# Altium schematic sheet H-9220 Backplane Board - Interface (REV07).SchDoc
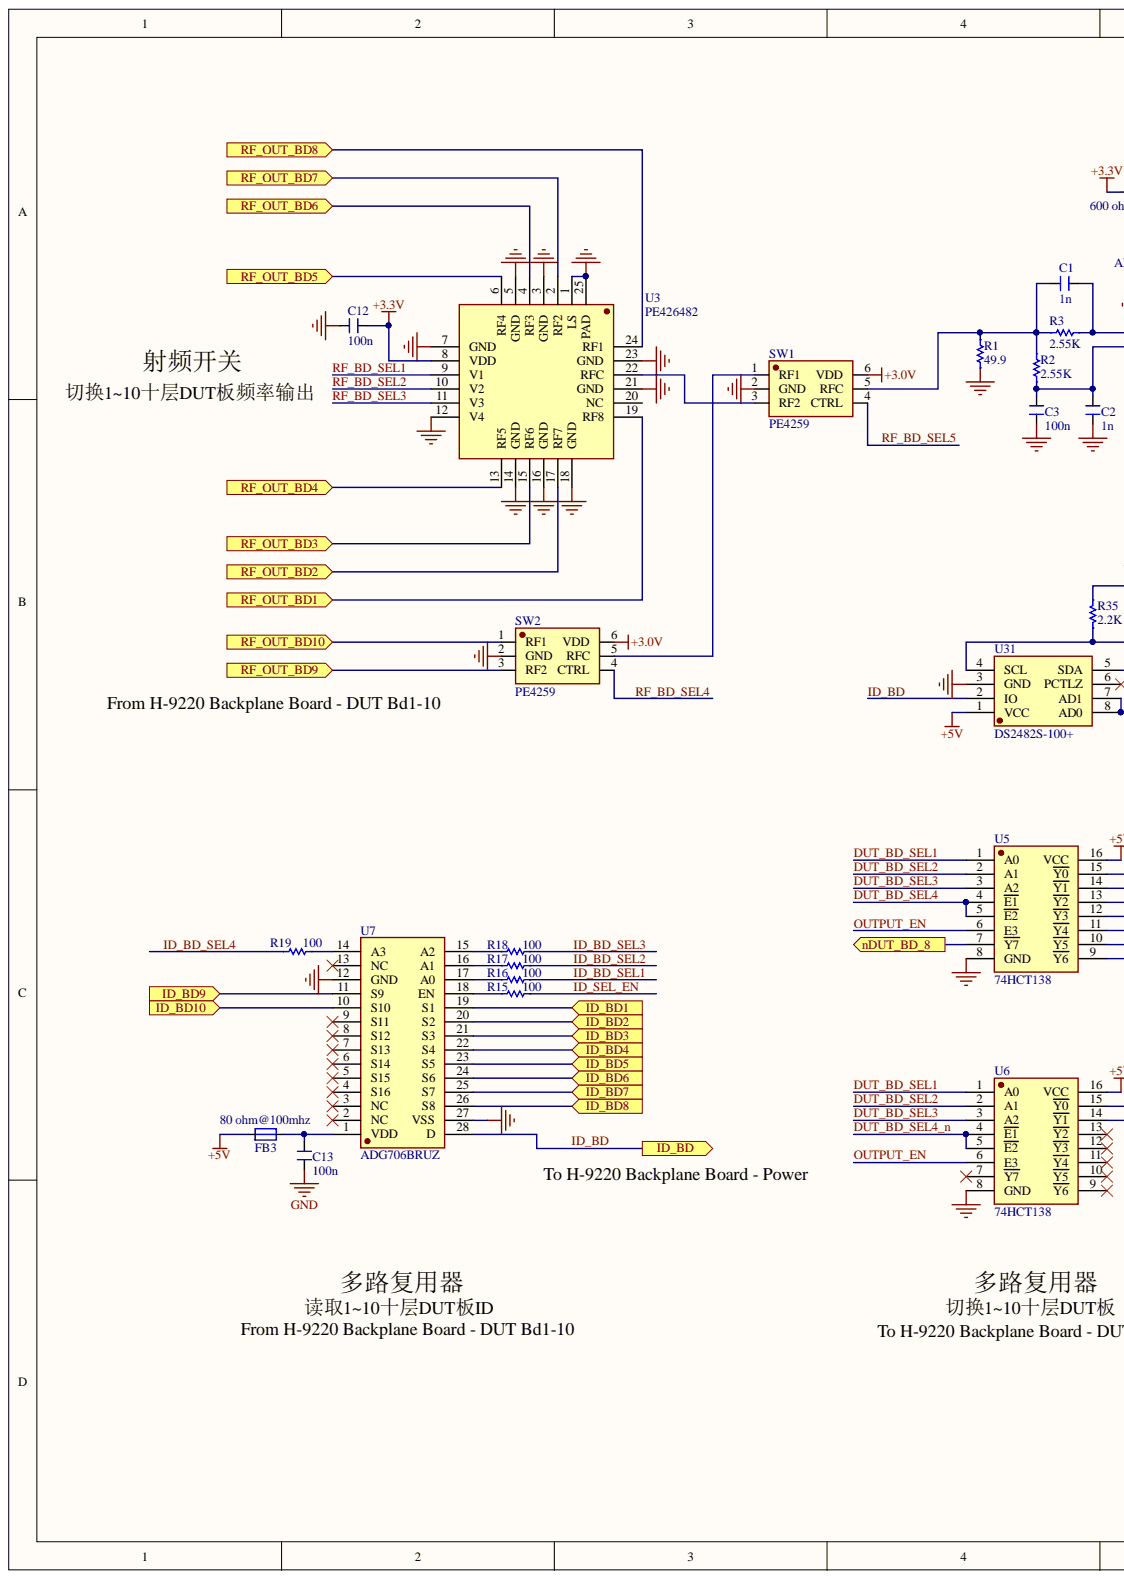
[diagram p0: H-9220 Backplane Board - Interface (REV07).SchDoc, left]
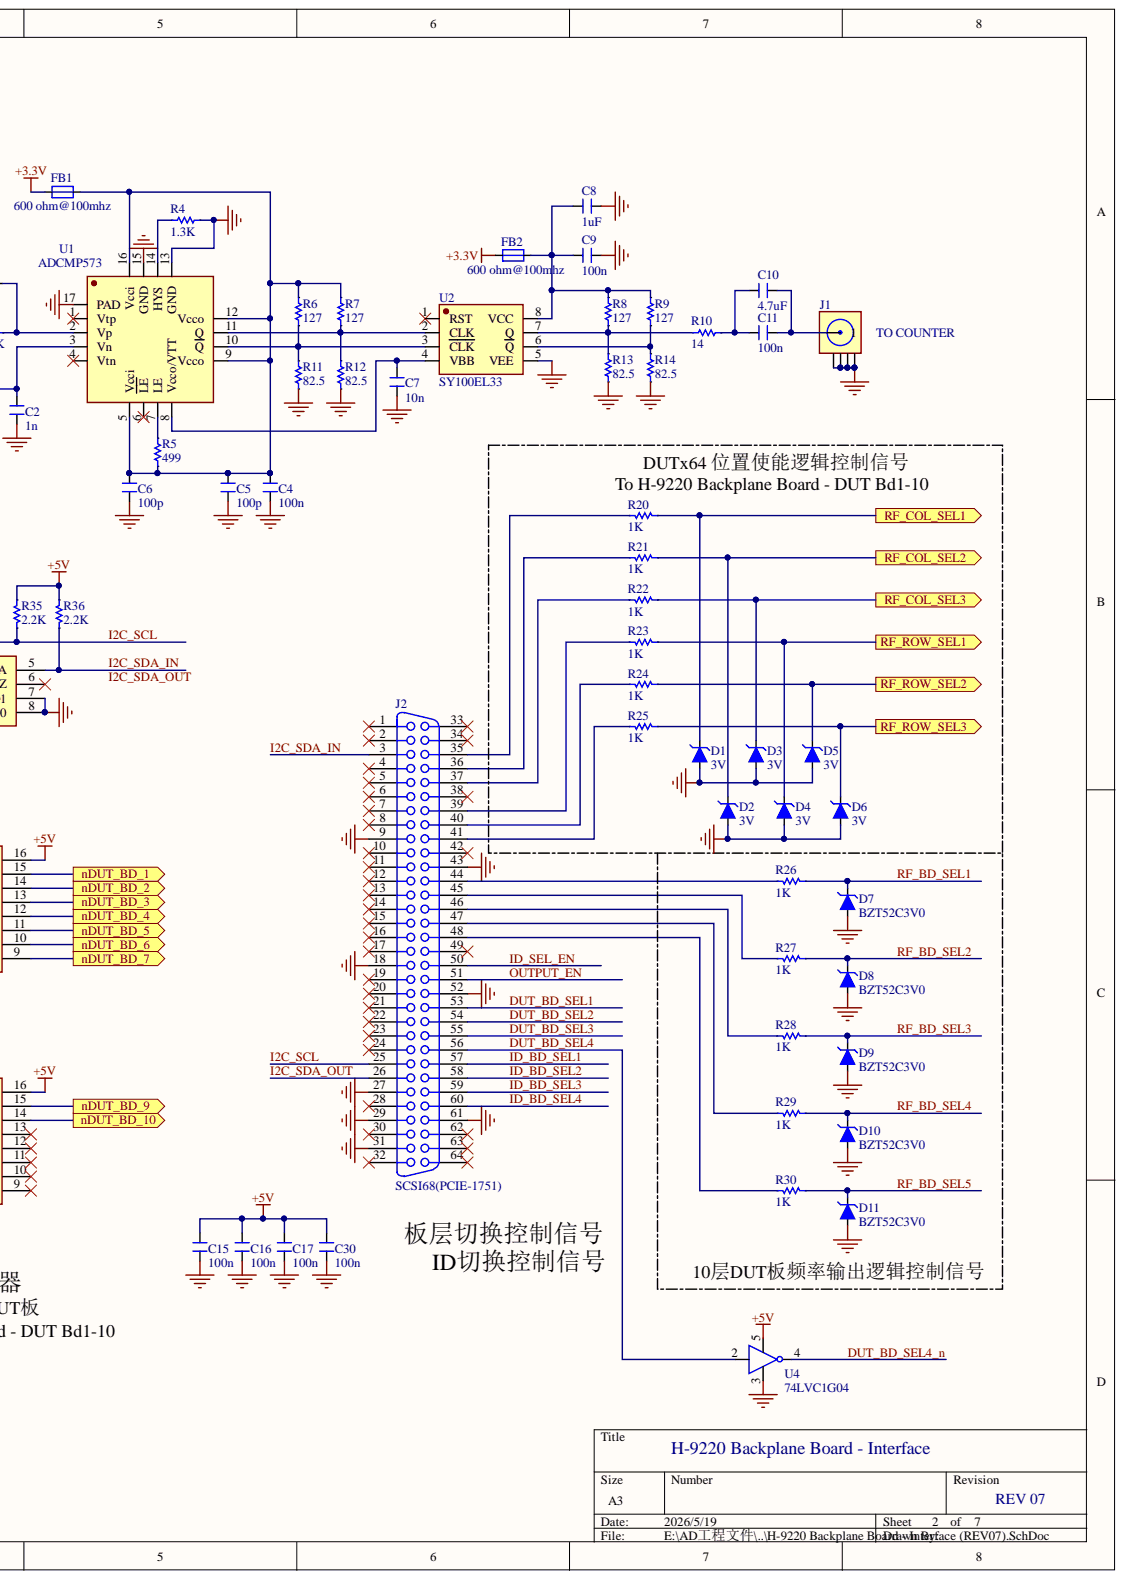
[diagram p1: H-9220 Backplane Board - Interface (REV07).SchDoc, right]

## Parts
C1  1n  [C-0603]
C2  1n  [C-0603]
C3  100n  [C-0603]
C4  100n  [C-0603]
C5  100p  [C-0603]
C6  100p  [C-0603]
C7  10n  [C-0603]
C8  1uF  [C-0805]
C9  100n  [C-0603]
C10  4.7uF  [C-0805]
C11  100n  [C-0603]
C12  100n  [C-0603]
C13  100n  [C-0603]
C15  100n  [C-0603]
C16  100n  [C-0603]
C17  100n  [C-0603]
C30  100n  [C-0603]
D1  3V  [SOD-123W]
D2  3V  [SOD-123W]
D3  3V  [SOD-123W]
D4  3V  [SOD-123W]
D5  3V  [SOD-123W]
D6  3V  [SOD-123W]
D7  BZT52C3V0  [SOD-123W]
D8  BZT52C3V0  [SOD-123W]
D9  BZT52C3V0  [SOD-123W]
D10  BZT52C3V0  [SOD-123W]
D11  BZT52C3V0  [SOD-123W]
FB1  600 ohm@100mhz  [R-0805]
FB2  600 ohm@100mhz  [R-0805]
FB3  80 ohm@100mhz  [R-0805]
J1  TO COUNTER  [SMA-V]
J2  SCSI68(PCIE-1751)  [CON-2x32P (P=2.54MM)]
R1  49.9  [R-0603]
R2  2.55K  [R-0603]
R3  2.55K  [R-0603]
R4  1.3K  [R-0603]
R5  499  [R-0603]
R6  127  [R-0603]
R7  127  [R-0603]
R8  127  [R-0603]
R9  127  [R-0603]
R10  14  [R-0603]
R11  82.5  [R-0603]
R12  82.5  [R-0603]
R13  82.5  [R-0603]
R14  82.5  [R-0603]
R15  100  [R-0603]
R16  100  [R-0603]
R17  100  [R-0603]
R18  100  [R-0603]
R19  100  [R-0603]
R20  1K  [R-0603]
R21  1K  [R-0603]
R22  1K  [R-0603]
R23  1K  [R-0603]
R24  1K  [R-0603]
R25  1K  [R-0603]
R26  1K  [R-0603]
R27  1K  [R-0603]
R28  1K  [R-0603]
R29  1K  [R-0603]
R30  1K  [R-0603]
R35  2.2K  [R-0805]
R36  2.2K  [R-0805]
SW1  PE4259  [SC70-6]
SW2  PE4259  [SC70-6]
U1  ADCMP573  [QFN-16 (P=0.5mm)]
U2  SY100EL33  [SOIC-08 (Body=3.9MM)]
U3  PE426482  [QFN-24 (P=0.5MM)]
U4  74LVC1G04  [SOT23-5]
U5  74HCT138  [SOIC-16 (BODY=3.9MM)]
U6  74HCT138  [SOIC-16 (BODY=3.9MM)]
U7  ADG706BRUZ  [TSSOP-28 (P=0.65MM)]
U31  DS2482S-100+  [SOIC-08 (Body=3.9MM)]

## Ports
ID_BD, ID_BD1, ID_BD2, ID_BD3, ID_BD4, ID_BD5, ID_BD6, ID_BD7, ID_BD8, ID_BD9, ID_BD10, nDUT_BD_1, nDUT_BD_2, nDUT_BD_3, nDUT_BD_4, nDUT_BD_5, nDUT_BD_6, nDUT_BD_7, nDUT_BD_8, nDUT_BD_9, nDUT_BD_10, RF_COL_SEL1, RF_COL_SEL2, RF_COL_SEL3, RF_OUT_BD1, RF_OUT_BD2, RF_OUT_BD3, RF_OUT_BD4, RF_OUT_BD5, RF_OUT_BD6, RF_OUT_BD7, RF_OUT_BD8, RF_OUT_BD9, RF_OUT_BD10, RF_ROW_SEL1, RF_ROW_SEL2, RF_ROW_SEL3

## Nets
+3.0V: SW1.6(VDD) SW2.6(VDD)
+3.3V: C12.1 FB1.1 FB2.1 U3.8(VDD)
+5V: C15.1 C16.1 C17.1 C30.1 FB3.1 R35.2 R36.2 U4.5 U5.16(VCC) U6.16(VCC) U31.1(VCC)
GND: C2.2 C3.2 C4.1 C5.2 C6.2 C7.1 C8.2 C9.2 C12.2 C13.1 C15.2 C16.2 C17.2 C30.2 D1.A D2.A D3.A D4.A D5.A D6.A D7.A D8.A D9.A D10.A D11.A J1.2 J1.3 J1.4 J1.5 J2.9 J2.18 J2.27 J2.29 J2.31 J2.43 J2.52 J2.61 R1.2 R4.1 R11.1 R12.1 R13.1 R14.1 SW1.2(GND) SW2.2(GND) U1.13(GND) U1.15(GND) U1.17(PAD) U2.5(VEE) U3.1(LS) U3.3(GND) U3.5(GND) U3.7(GND) U3.12(V4) U3.14(GND) U3.16(GND) U3.18(GND) U3.21(GND) U3.23(GND) U3.25(PAD) U4.3 U5.8(GND) U6.8(GND) U7.12(GND) U7.27(VSS) U31.3(GND) U31.7(AD1) U31.8(AD0)
DUT_BD_SEL1: J2.53 U5.1(A0) U6.1(A0)
DUT_BD_SEL2: J2.54 U5.2(A1) U6.2(A1)
DUT_BD_SEL3: J2.55 U5.3(A2) U6.3(A2)
DUT_BD_SEL4: J2.56 U4.2 U5.4(E1) U5.5(E2)
DUT_BD_SEL4_n: U4.4 U6.4(E1) U6.5(E2)
I2C_SCL: J2.25 R35.1 U31.4(SCL)
I2C_SDA_IN: J2.3 J2.26 R36.1 U31.5(SDA)
ID_BD: U7.28(D) U31.2(IO) ; port ID_BD
ID_BD1: U7.19(S1) ; port ID_BD1
ID_BD2: U7.20(S2) ; port ID_BD2
ID_BD3: U7.21(S3) ; port ID_BD3
ID_BD4: U7.22(S4) ; port ID_BD4
ID_BD5: U7.23(S5) ; port ID_BD5
ID_BD6: U7.24(S6) ; port ID_BD6
ID_BD7: U7.25(S7) ; port ID_BD7
ID_BD8: U7.26(S8) ; port ID_BD8
ID_BD9: U7.11(S9) ; port ID_BD9
ID_BD10: U7.10(S10) ; port ID_BD10
ID_BD_SEL1: J2.57 R16.1
ID_BD_SEL2: J2.58 R17.1
ID_BD_SEL3: J2.59 R18.1
ID_BD_SEL4: J2.60 R19.1
ID_SEL_EN: J2.50 R15.1
nDUT_BD_1: U5.15(Y0) ; port nDUT_BD_1
nDUT_BD_2: U5.14(Y1) ; port nDUT_BD_2
nDUT_BD_3: U5.13(Y2) ; port nDUT_BD_3
nDUT_BD_4: U5.12(Y3) ; port nDUT_BD_4
nDUT_BD_5: U5.11(Y4) ; port nDUT_BD_5
nDUT_BD_6: U5.10(Y5) ; port nDUT_BD_6
nDUT_BD_7: U5.9(Y6) ; port nDUT_BD_7
nDUT_BD_8: U5.7(Y7) ; port nDUT_BD_8
nDUT_BD_9: U6.15(Y0) ; port nDUT_BD_9
nDUT_BD_10: U6.14(Y1) ; port nDUT_BD_10
OUTPUT_EN: J2.51 U5.6(E3) U6.6(E3)
RF_BD_SEL1: D7.K R26.1 U3.9(V1)
RF_BD_SEL2: D8.K R27.1 U3.10(V2)
RF_BD_SEL3: D9.K R28.1 U3.11(V3)
RF_BD_SEL4: D10.K R29.1 SW2.4(CTRL)
RF_BD_SEL5: D11.K R30.1 SW1.4(CTRL)
RF_COL_SEL1: D1.K R20.1 ; port RF_COL_SEL1
RF_COL_SEL2: D2.K R21.1 ; port RF_COL_SEL2
RF_COL_SEL3: D3.K R22.1 ; port RF_COL_SEL3
RF_OUT_BD1: U3.19(RF8) ; port RF_OUT_BD1
RF_OUT_BD2: U3.17(RF7) ; port RF_OUT_BD2
RF_OUT_BD3: U3.15(RF6) ; port RF_OUT_BD3
RF_OUT_BD4: U3.13(RF5) ; port RF_OUT_BD4
RF_OUT_BD5: U3.6(RF4) ; port RF_OUT_BD5
RF_OUT_BD6: U3.4(RF3) ; port RF_OUT_BD6
RF_OUT_BD7: U3.2(RF2) ; port RF_OUT_BD7
RF_OUT_BD8: U3.24(RF1) ; port RF_OUT_BD8
RF_OUT_BD9: SW2.3(RF2) ; port RF_OUT_BD9
RF_OUT_BD10: SW2.1(RF1) ; port RF_OUT_BD10
RF_ROW_SEL1: D4.K R23.1 ; port RF_ROW_SEL1
RF_ROW_SEL2: D5.K R24.1 ; port RF_ROW_SEL2
RF_ROW_SEL3: D6.K R25.1 ; port RF_ROW_SEL3
NetC1_1: C1.1 R1.1 R2.2 R3.1 SW1.5(RFC)
NetC1_2: C1.2 R3.2 U1.2(Vp)
NetC2_1: C2.1 C3.1 R2.1 U1.3(Vn)
NetC4_2: C4.2 C5.1 C6.1 FB1.2 R5.2 R6.2 R7.2 U1.5(Vcci) U1.9(Vcco) U1.12(Vcco) U1.16(Vcci)
NetC7_2: C7.2 U1.8(Vcco/VTT) U2.4(VBB)
NetC8_1: C8.1 C9.1 FB2.2 R8.2 R9.2 U2.8(VCC)
NetC10_1: C10.1 C11.1 R10.1
NetC10_2: C10.2 C11.2 J1.1
NetC13_2: C13.2 FB3.2 U7.1(VDD)
NetJ2_35: J2.35 R20.2
NetJ2_36: J2.36 R21.2
NetJ2_37: J2.37 R22.2
NetJ2_39: J2.39 R23.2
NetJ2_40: J2.40 R24.2
NetJ2_41: J2.41 R25.2
NetJ2_44: J2.44 R26.2
NetJ2_45: J2.45 R27.2
NetJ2_46: J2.46 R28.2
NetJ2_47: J2.47 R29.2
NetJ2_48: J2.48 R30.2
NetR4_2: R4.2 U1.14(HYS)
NetR5_1: R5.1 U1.7(LE)
NetR6_1: R6.1 R11.2 U1.10(Q) U2.3(CLK)
NetR7_1: R7.1 R12.2 U1.11(Q) U2.2(CLK)
NetR8_1: R8.1 R10.2 R13.2 U2.7(Q)
NetR9_1: R9.1 R14.2 U2.6(Q)
NetR15_2: R15.2 U7.18(EN)
NetR16_2: R16.2 U7.17(A0)
NetR17_2: R17.2 U7.16(A1)
NetR18_2: R18.2 U7.15(A2)
NetR19_2: R19.2 U7.14(A3)
NetSW1_1: SW1.1(RF1) SW2.5(RFC)
NetSW1_3: SW1.3(RF2) U3.22(RFC)

Not connected: J2 1 2 4 5 6 7 8 10 11 12 13 14 15 16 17 19 20 21 22 23 24 28 30 32 33 34 38 42 49 62 63 64; U1 1(Vtp) 4(Vtn) 6(LE); U2 1(RST); U3 20(NC); U6 7(Y7) 9(Y6) 10(Y5) 11(Y4) 12(Y3) 13(Y2); U7 2(NC) 3(NC) 4(S16) 5(S15) 6(S14) 7(S13) 8(S12) 9(S11) 13(NC); U31 6(PCTLZ)

## Library data of the parts
C1-C13 C15-C17 C30 = CAP: Component Kind: Standard; Library Name: HW SCH Lib.SCHLIB
D1-D11 = Diode Zener: Component Kind: Standard; Library Name: HW SCH Lib.SCHLIB
FB1-FB3 = FB1: Component Kind: Standard; Library Name: HW SCH Lib.SCHLIB
J1 = SMA: SMA Connector; Component Kind: Standard; Library Name: HW SCH Lib.SCHLIB
J2 = SCSI68 (PCIE-1751): Component Kind: Standard; Library Name: HW SCH Lib.SCHLIB
R1-R30 R35 R36 = RES: : 10K; Component Kind: Standard; Library Name: HW SCH Lib.SCHLIB
U2 = SY100EL33: integrated ÷4 divider; Component Kind: Standard; Library Name: HW SCH Lib.SCHLIB
U3 = PE426482: RF Switch; Component Kind: Standard; Library Name: HW SCH Lib.SCHLIB
U7 = ADG706BRUZ: 16-Channel Multiplexers; Component Kind: Standard; Library Name: HW SCH Lib.SCHLIB
U31 = DS2482S-100+: I2C-to-1-Wire bridge device; Component Kind: Standard; Library Name: HW SCH Lib.SCHLIB
SW1 SW2 = PE4259: Component Kind: Standard; Library Name: HW SCH Lib.SCHLIB
U1 = ADCMP573: Component Kind: Standard; Library Name: HW SCH Lib.SCHLIB
U4 = 74LVC1G04: Component Kind: Standard; Library Name: HW SCH Lib.SCHLIB
U5 U6 = 74HCT138: Component Kind: Standard; Library Name: HW SCH Lib.SCHLIB
